# Altium schematic sheet STM32.SchDoc
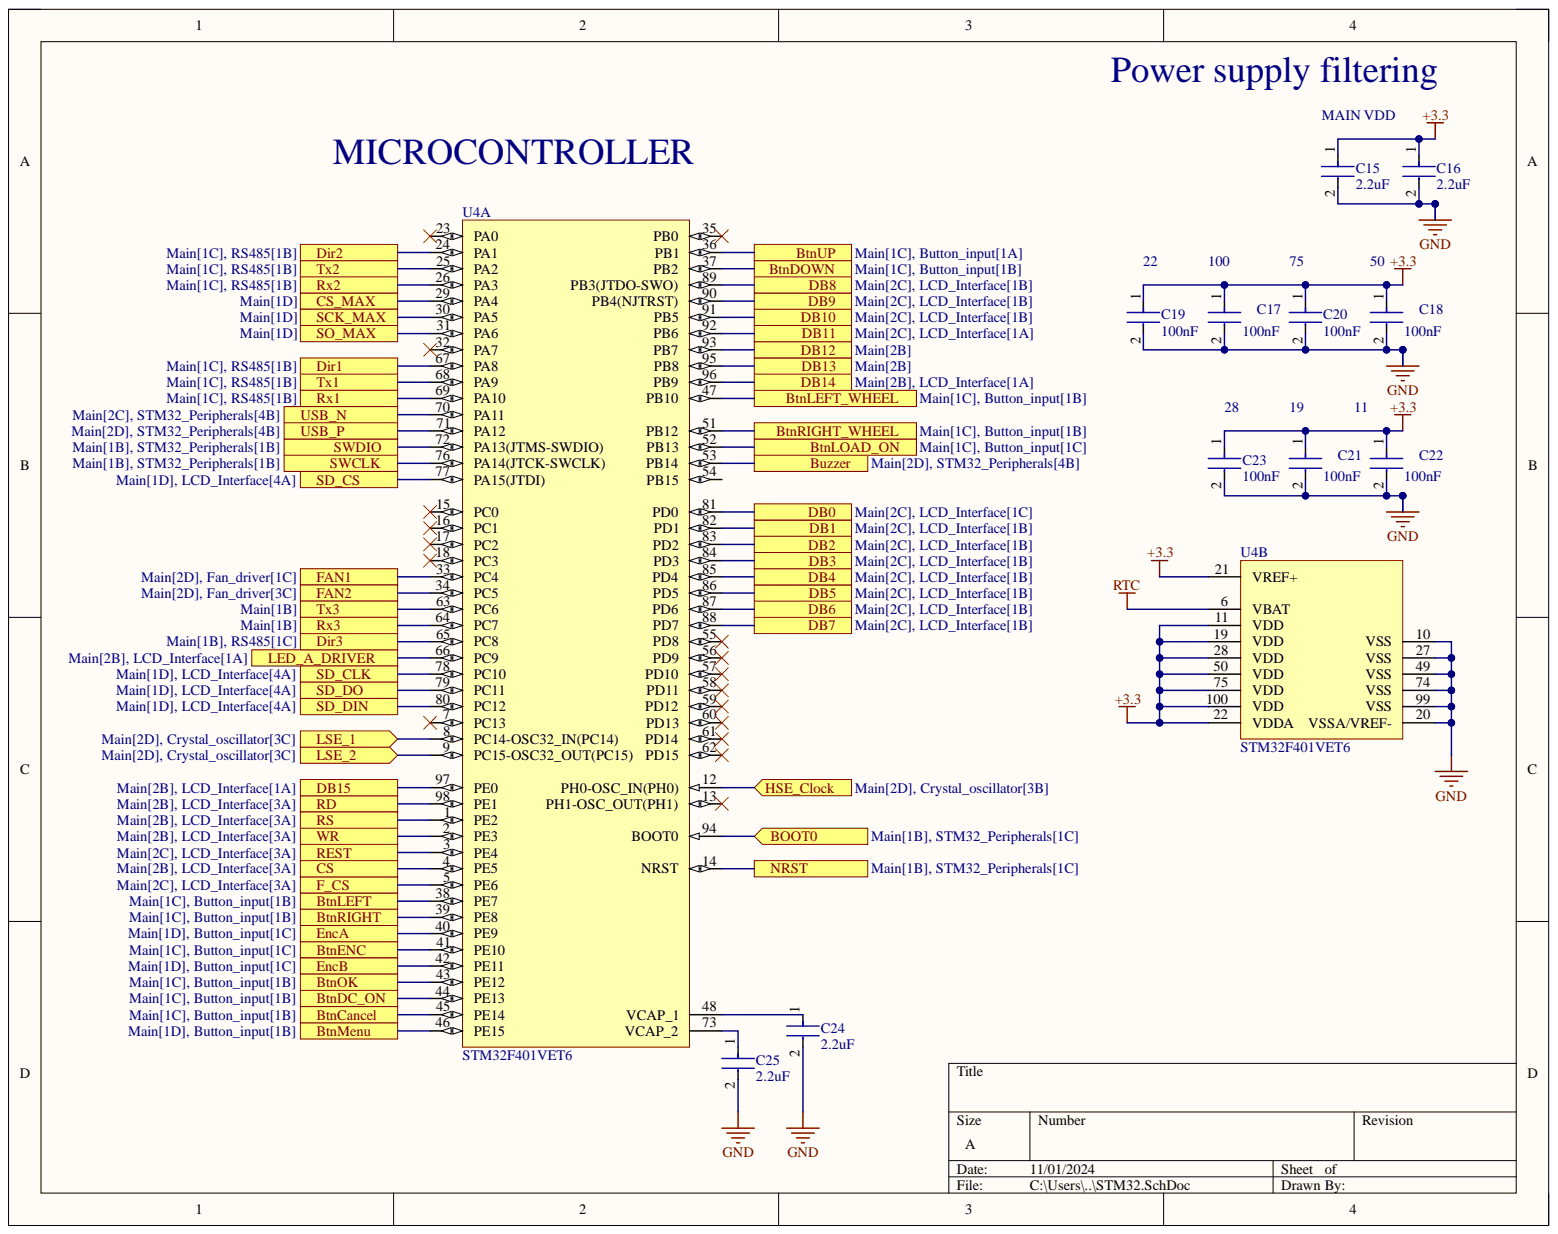
[diagram p0: STM32.SchDoc]

## Parts
C15  2.2uF  [FP-0805-L_2_01_0_2-W_1_25-IPC_B]
C16  2.2uF  [FP-0805-L_2_01_0_2-W_1_25-IPC_B]
C17  100nF  [FP-CGA3E-0_3-0_1-IPC_A]
C18  100nF  [FP-CGA3E-0_3-0_1-IPC_A]
C19  100nF  [FP-CGA3E-0_3-0_1-IPC_A]
C20  100nF  [FP-CGA3E-0_3-0_1-IPC_A]
C21  100nF  [FP-CGA3E-0_3-0_1-IPC_A]
C22  100nF  [FP-CGA3E-0_3-0_1-IPC_A]
C23  100nF  [FP-CGA3E-0_3-0_1-IPC_A]
C24  2.2uF  [FP-0805-L_2_01_0_2-W_1_25-IPC_B]
C25  2.2uF  [FP-0805-L_2_01_0_2-W_1_25-IPC_B]
U4  STM32F401VET6  [STM-LQFP100_L]  (parts A,B)

## Ports
BOOT0, BtnCancel, BtnDC_ON, BtnDOWN, BtnENC, BtnLEFT, BtnLEFT_WHEEL, BtnLOAD_ON, BtnMenu, BtnOK, BtnRIGHT, BtnRIGHT_WHEEL, BtnUP, Buzzer, CS, CS_MAX, DB0, DB1, DB2, DB3, DB4, DB5, DB6, DB7, DB8, DB9, DB10, DB11, DB12, DB13, DB14, DB15, Dir1, Dir2, Dir3, EncA, EncB, F_CS, FAN1, FAN2, HSE_Clock, LED_A_DRIVER, LSE_1, LSE_2, NRST, RD, REST, RS, Rx1, Rx2, Rx3, SCK_MAX, SD_CLK, SD_CS, SD_DIN, SD_DO, SO_MAX, SWCLK, SWDIO, Tx1, Tx2, Tx3, USB_N, USB_P, WR

## Nets
+3.3: C15.1 C16.1 C17.1 C18.1 C19.1 C20.1 C21.1 C22.1 C23.1 U4.11(VDD) U4.19(VDD) U4.21(VREF+) U4.22(VDDA) U4.28(VDD) U4.50(VDD) U4.75(VDD) U4.100(VDD)
GND: C15.2 C16.2 C17.2 C18.2 C19.2 C20.2 C21.2 C22.2 C23.2 C24.2 C25.2 U4.10(VSS) U4.20(VSSA/VREF-) U4.27(VSS) U4.49(VSS) U4.74(VSS) U4.99(VSS)
RTC: U4.6(VBAT)
BOOT0: U4.94(BOOT0) ; port BOOT0
BtnCancel: U4.45(PE14) ; port BtnCancel
BtnDC_ON: U4.44(PE13) ; port BtnDC_ON
BtnDOWN: U4.37(PB2) ; port BtnDOWN
BtnENC: U4.41(PE10) ; port BtnENC
BtnLEFT: U4.38(PE7) ; port BtnLEFT
BtnLEFT_WHEEL: U4.47(PB10) ; port BtnLEFT_WHEEL
BtnLOAD_ON: U4.52(PB13) ; port BtnLOAD_ON
BtnMenu: U4.46(PE15) ; port BtnMenu
BtnOK: U4.43(PE12) ; port BtnOK
BtnRIGHT: U4.39(PE8) ; port BtnRIGHT
BtnRIGHT_WHEEL: U4.51(PB12) ; port BtnRIGHT_WHEEL
BtnUP: U4.36(PB1) ; port BtnUP
Buzzer: U4.53(PB14) ; port Buzzer
CS: U4.4(PE5) ; port CS
CS_MAX: U4.29(PA4) ; port CS_MAX
DB0: U4.81(PD0) ; port DB0
DB1: U4.82(PD1) ; port DB1
DB2: U4.83(PD2) ; port DB2
DB3: U4.84(PD3) ; port DB3
DB4: U4.85(PD4) ; port DB4
DB5: U4.86(PD5) ; port DB5
DB6: U4.87(PD6) ; port DB6
DB7: U4.88(PD7) ; port DB7
DB8: U4.89(PB3(JTDO-SWO)) ; port DB8
DB9: U4.90(PB4(NJTRST)) ; port DB9
DB10: U4.91(PB5) ; port DB10
DB11: U4.92(PB6) ; port DB11
DB12: U4.93(PB7) ; port DB12
DB13: U4.95(PB8) ; port DB13
DB14: U4.96(PB9) ; port DB14
DB15: U4.97(PE0) ; port DB15
Dir1: U4.67(PA8) ; port Dir1
Dir2: U4.24(PA1) ; port Dir2
Dir3: U4.65(PC8) ; port Dir3
EncA: U4.40(PE9) ; port EncA
EncB: U4.42(PE11) ; port EncB
F_CS: U4.5(PE6) ; port F_CS
FAN1: U4.33(PC4) ; port FAN1
FAN2: U4.34(PC5) ; port FAN2
HSE_Clock: U4.12(PH0-OSC_IN(PH0)) ; port HSE_Clock
LED_A_DRIVER: U4.66(PC9) ; port LED_A_DRIVER
LSE_1: U4.8(PC14-OSC32_IN(PC14)) ; port LSE_1
LSE_2: U4.9(PC15-OSC32_OUT(PC15)) ; port LSE_2
NRST: U4.14(NRST) ; port NRST
RD: U4.98(PE1) ; port RD
REST: U4.3(PE4) ; port REST
RS: U4.1(PE2) ; port RS
Rx1: U4.69(PA10) ; port Rx1
Rx2: U4.26(PA3) ; port Rx2
Rx3: U4.64(PC7) ; port Rx3
SCK_MAX: U4.30(PA5) ; port SCK_MAX
SD_CLK: U4.78(PC10) ; port SD_CLK
SD_CS: U4.77(PA15(JTDI)) ; port SD_CS
SD_DIN: U4.80(PC12) ; port SD_DIN
SD_DO: U4.79(PC11) ; port SD_DO
SO_MAX: U4.31(PA6) ; port SO_MAX
SWCLK: U4.76(PA14(JTCK-SWCLK)) ; port SWCLK
SWDIO: U4.72(PA13(JTMS-SWDIO)) ; port SWDIO
Tx1: U4.68(PA9) ; port Tx1
Tx2: U4.25(PA2) ; port Tx2
Tx3: U4.63(PC6) ; port Tx3
USB_N: U4.70(PA11) ; port USB_N
USB_P: U4.71(PA12) ; port USB_P
WR: U4.2(PE3) ; port WR
NetC24_1: C24.1 U4.48(VCAP_1)
NetC25_1: C25.1 U4.73(VCAP_2)
NetU4_7: U4.7(PC13)
NetU4_13: U4.13(PH1-OSC_OUT(PH1))
NetU4_15: U4.15(PC0)
NetU4_16: U4.16(PC1)
NetU4_17: U4.17(PC2)
NetU4_18: U4.18(PC3)
NetU4_23: U4.23(PA0)
NetU4_32: U4.32(PA7)
NetU4_35: U4.35(PB0)
NetU4_54: U4.54(PB15)
NetU4_55: U4.55(PD8)
NetU4_56: U4.56(PD9)
NetU4_57: U4.57(PD10)
NetU4_58: U4.58(PD11)
NetU4_59: U4.59(PD12)
NetU4_60: U4.60(PD13)
NetU4_61: U4.61(PD14)
NetU4_62: U4.62(PD15)

## Library data of the parts
C15 C16 C24 C25 = CMP-2007-04174-2: General Purpose Ceramic Capacitor, 0805, 10nF, 10%, X7R, 15%, 100V; Capacitance: 100nF; Case Code (Imperial): 0805; Case Code (Metric): 2012; Case/Package: 0805; Component Kind: Standard; Construction: Flat; Contact Plating: Tin; Depth: 1.25mm; Dielectric: X7R; Dielectric Material: Ceramic; Height: 1.4mm; Lead Free: Lead Free; Length: 2.01mm; Library Name: Altium Content Vault; Max Operating Temperature: 125°C; Min Operating Temperature: -55°C; Mount: Surface Mount; Number of Pins: 2; Package Quantity: 2000; Packaging: Tape and Reel; Radiation Hardening: No; REACH SVHC: No SVHC; RoHS Compliant: Yes; Series: -; Termination: SMD/SMT; Thickness: 0.055inch; Tolerance: 10%; Voltage: 100V; Voltage Rating: 100V; Voltage Rating (DC): 100V; Width: 0.049inch
C17-C23 = CMP-2000-07546-2: Cap Ceramic 100nF 50V X7R 10% Pad SMD 0603 +125°C Automotive T/R; Capacitance: 100nF; Case Code (Imperial): 0603; Case Code (Metric): 1608; Case/Package: 0603; Component Kind: Standard; Composition: Ceramic; Depth: 0.8mm; Dielectric: X7R; Height: 0.8mm; Lead Free: Lead Free; Length: 1.6mm; Library Name: Altium Content Vault; Max Operating Temperature: 125°C; Min Operating Temperature: -55°C; Mount: Surface Mount; Package Quantity: 1; Packaging: Tape and Reel; Radiation Hardening: No; Ratings: AEC-Q200; REACH SVHC: No SVHC; Termination: SMD/SMT; Thickness: 0.035inch; Tolerance: 10%; Voltage: 50V; Voltage Rating: 50V; Voltage Rating (DC): 50V; Weight: 7.1E-05oz; Width: 0.8mm
U4 = CMP-0243-00155-1: ARM Cortex-M4 32-bit MCU+FPU, 512 KB Flash, 96 KB Internal RAM, 81 I/Os, 100-Pin LQFP, -40 to 85 degC, Tray; FLASH Size (Prog) (kB): 512; I/Os (High Current): 81; Internal RAM Size (kB): 96; Operating Frequency(F) (Processor speed) (MHz): 84; Supply Voltage(Vcc) min (V): 1.7; Component Kind: Standard; ComponentLink1Description: Manufacturer URL; ComponentLink1URL: http://www.st.com/; ComponentLink2Description: Datasheet; ComponentLink2URL: http://www.st.com/st-web-ui/static/active/en/resource/technical/document/datasheet/DM00102166.pdf; Core: ARM Cortex-M4; DatasheetVersion: Rev. 3; Library Name: Altium Content Vault; Mounting Technology: Surface Mount; Package Description: LQFP100; Package Reference: 100-Pin Low Profile Quad Flat Package, Body 14 x 14 mm, Pitch 0.5 mm; Packing: Tray
